# Altium schematic sheet PAGE09 Connectors.SchDoc
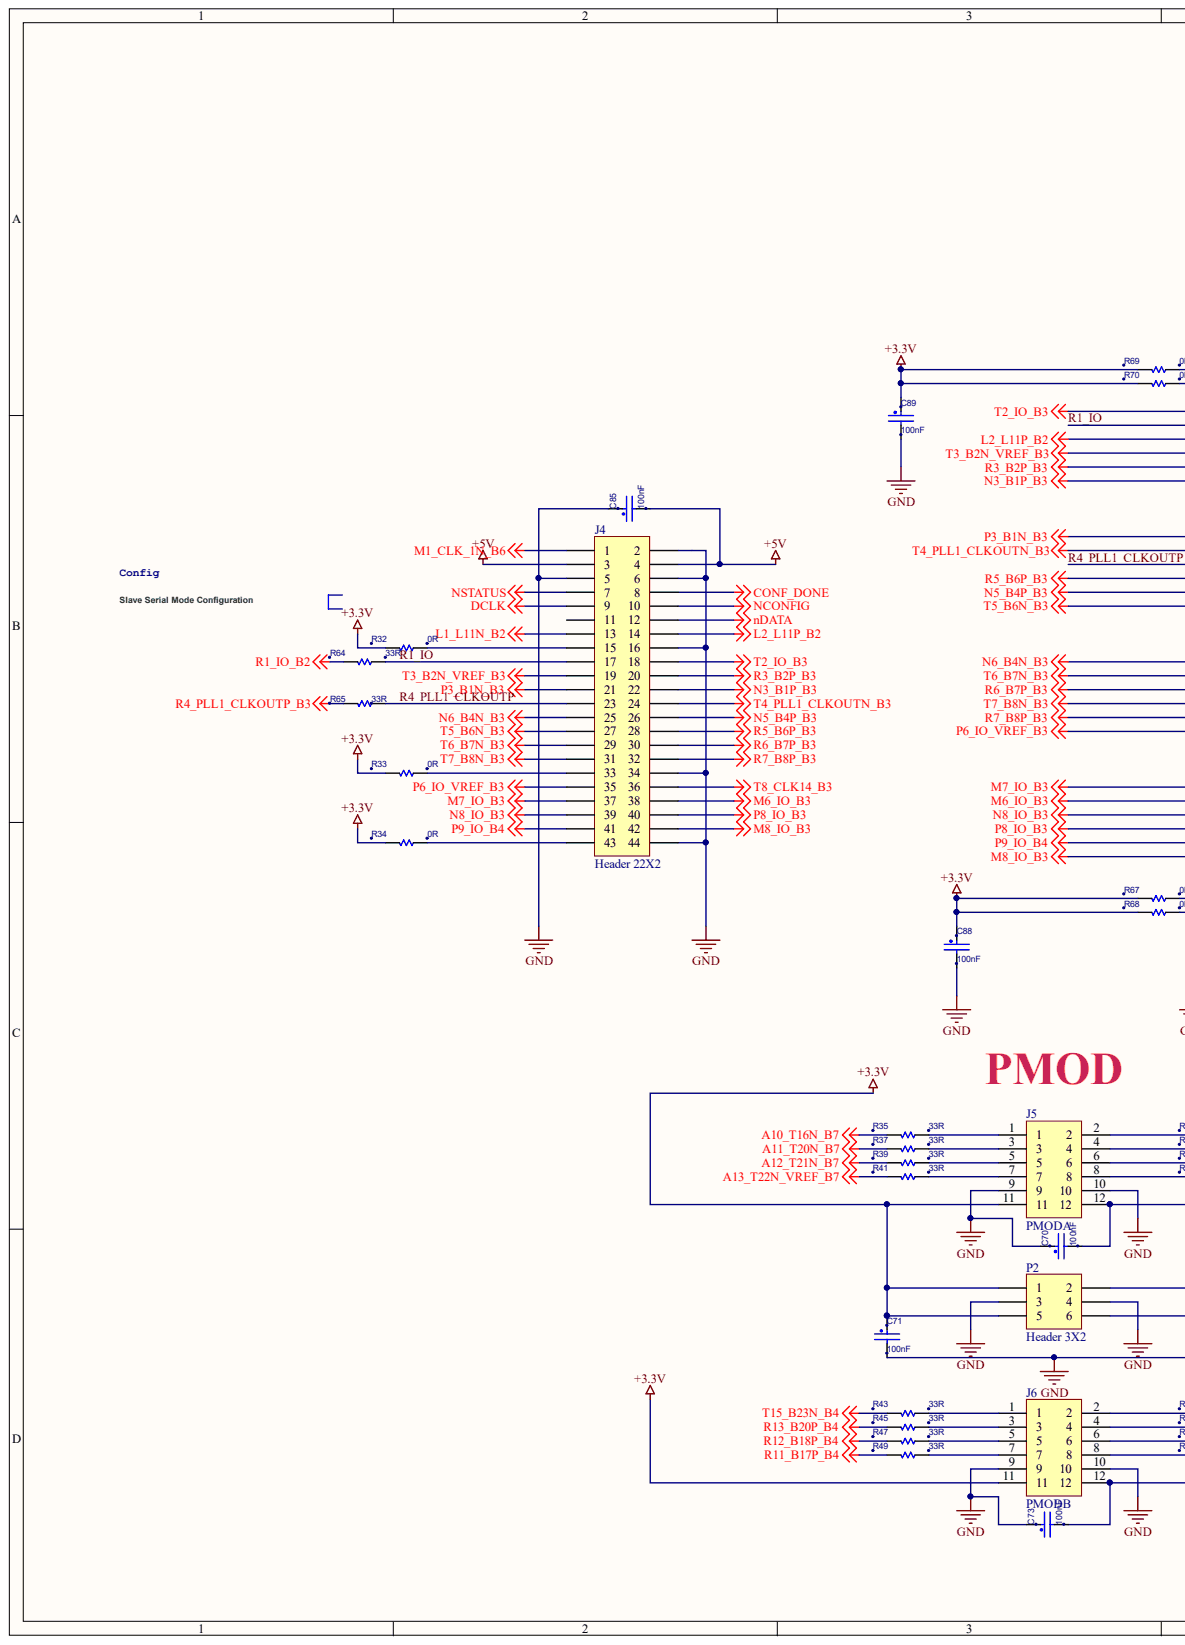
[diagram p0: PAGE09 Connectors.SchDoc, left]
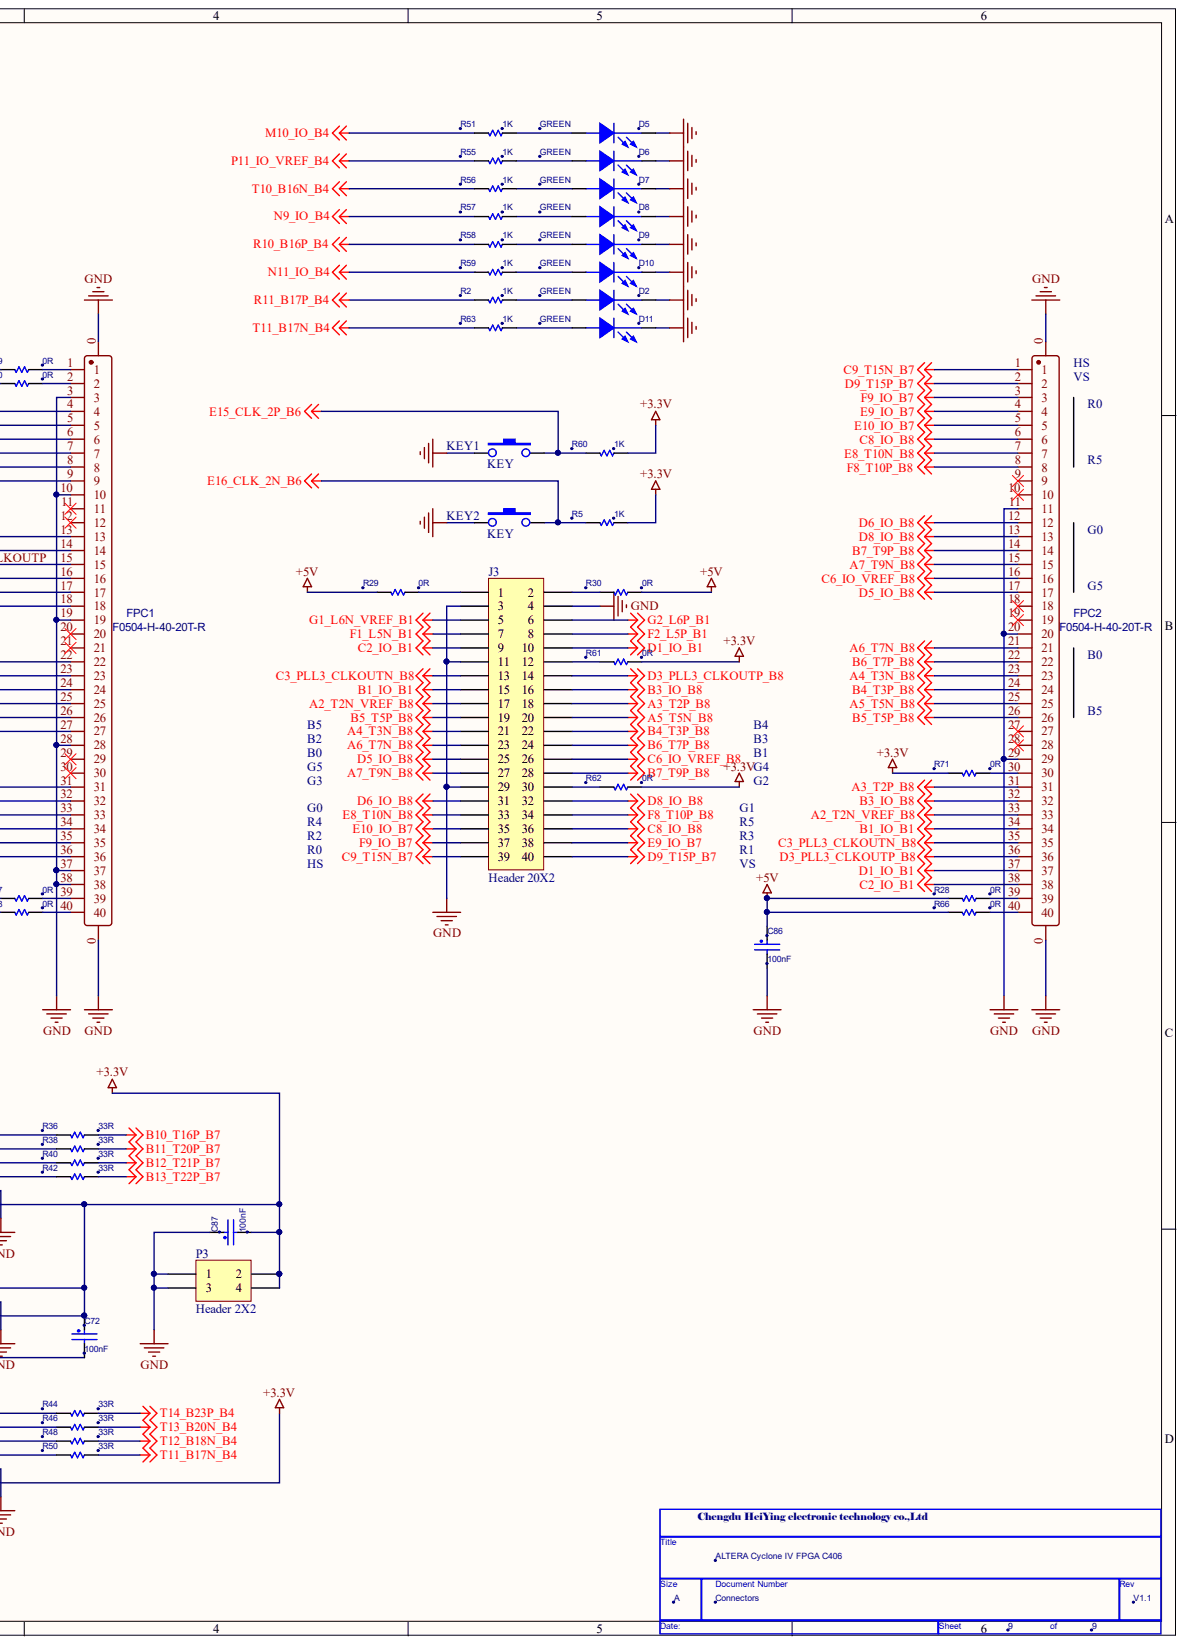
[diagram p1: PAGE09 Connectors.SchDoc, right]

## Parts
C70  100nF  [C0402]
C71  100nF  [C0402]
C72  100nF  [C0402]
C73  100nF  [C0402]
C85  100nF  [C0402]
C86  100nF  [C0402]
C87  100nF  [C0402]
C88  100nF  [C0402]
C89  100nF  [C0402]
D2  GREEN  [Q0603]
D5  GREEN  [Q0603]
D6  GREEN  [Q0603]
D7  GREEN  [Q0603]
D8  GREEN  [Q0603]
D9  GREEN  [Q0603]
D10  GREEN  [Q0603]
D11  GREEN  [Q0603]
FPC1  F0504-H-40-20T-R  [FPC-SMD_40P-P0.50_L5.8-W23.5]
FPC2  F0504-H-40-20T-R  [FPC-SMD_40P-P0.50_L5.8-W23.5]
J3  Header 20X2  [HDR2X20]
J4  Header 22X2  [HDR2X22]
J5  PMODA  [2X6P RIGHT]
J6  PMODB  [2X6P RIGHT]
KEY1  KEY  [SMD-3X6X2.5]
KEY2  KEY  [SMD-3X6X2.5]
P2  Header 3X2  [HDR2X3]
P3  Header 2X2  [HDR2X2]
R2  1K  [R0402]
R5  1K  [R0402]
R28  0R  [R0402]
R29  0R  [R0402]
R30  0R  [R0402]
R32  0R  [R0402]
R33  0R  [R0402]
R34  0R  [R0402]
R35  33R  [R0402]
R36  33R  [R0402]
R37  33R  [R0402]
R38  33R  [R0402]
R39  33R  [R0402]
R40  33R  [R0402]
R41  33R  [R0402]
R42  33R  [R0402]
R43  33R  [R0402]
R44  33R  [R0402]
R45  33R  [R0402]
R46  33R  [R0402]
R47  33R  [R0402]
R48  33R  [R0402]
R49  33R  [R0402]
R50  33R  [R0402]
R51  1K  [R0402]
R55  1K  [R0402]
R56  1K  [R0402]
R57  1K  [R0402]
R58  1K  [R0402]
R59  1K  [R0402]
R60  1K  [R0402]
R61  0R  [R0402]
R62  0R  [R0402]
R63  1K  [R0402]
R64  33R  [R0402]
R65  33R  [R0402]
R66  0R  [R0402]
R67  0R  [R0402]
R68  0R  [R0402]
R69  0R  [R0402]
R70  0R  [R0402]
R71  0R  [R0402]

## Nets
+3.3V: C70.2 C71.1 C72.1 C73.2 C87.2 C88.1 C89.1 J5.11 J5.12 J6.11 J6.12 P2.1 P2.2 P2.5 P2.6 P3.2 P3.4 R5.1 R32.2 R33.2 R34.2 R60.1 R61.1 R62.1 R67.2 R68.2 R69.2 R70.2 R71.2
+5V: C85.2 C86.1 J4.3 J4.4 R28.2 R29.2 R30.1 R66.2
A2_T2N_VREF_B8: FPC2.33 J3.17
A3_T2P_B8: FPC2.31 J3.18
A4_T3N_B8: FPC2.23 J3.21
A5_T5N_B8: FPC2.25 J3.20
A6_T7N_B8: FPC2.21 J3.23
A7_T9N_B8: FPC2.15 J3.27
A10_T16N_B7: R35.2
A11_T20N_B7: R37.2
A12_T21N_B7: R39.2
A13_T22N_VREF_B7: R41.2
B1_IO_B1: FPC2.34 J3.15
B3_IO_B8: FPC2.32 J3.16
B4_T3P_B8: FPC2.24 J3.22
B5_T5P_B8: FPC2.26 J3.19
B6_T7P_B8: FPC2.22 J3.24
B7_T9P_B8: FPC2.14 J3.28
B10_T16P_B7: R36.1
B11_T20P_B7: R38.1
B12_T21P_B7: R40.1
B13_T22P_B7: R42.1
C2_IO_B1: FPC2.38 J3.9
C3_PLL3_CLKOUTN_B8: FPC2.35 J3.13
C6_IO_VREF_B8: FPC2.16 J3.26
C8_IO_B8: FPC2.6 J3.36
C9_T15N_B7: FPC2.1 J3.39
CONF_DONE: J4.8
D1_IO_B1: FPC2.37 J3.10
D3_PLL3_CLKOUTP_B8: FPC2.36 J3.14
D5_IO_B8: FPC2.17 J3.25
D6_IO_B8: FPC2.12 J3.31
D8_IO_B8: FPC2.13 J3.32
D9_T15P_B7: FPC2.2 J3.40
DCLK: J4.9
E8_T10N_B8: FPC2.7 J3.33
E9_IO_B7: FPC2.4 J3.38
E10_IO_B7: FPC2.5 J3.35
E15_CLK_2P_B6: KEY1.3 R60.2
E16_CLK_2N_B6: KEY2.3 R5.2
F1_L5N_B1: J3.7
F2_L5P_B1: J3.8
F8_T10P_B8: FPC2.8 J3.34
F9_IO_B7: FPC2.3 J3.37
G1_L6N_VREF_B1: J3.5
G2_L6P_B1: J3.6
GND: C70.1 C71.2 C72.2 C73.1 C85.1 C86.2 C87.1 C88.2 C89.2 D2.2 D5.2 D6.2 D7.2 D8.2 D9.2 D10.2 D11.2 FPC1.0 FPC1.3 FPC1.10 FPC1.19 FPC1.28 FPC1.37 FPC1.38 FPC2.0 FPC2.11 FPC2.20 FPC2.29 J3.3 J3.4 J3.11 J3.29 J4.2 J4.5 J4.6 J4.16 J4.34 J4.44 J5.9 J5.10 J6.9 J6.10 KEY1.1 KEY2.1 P2.3 P2.4 P3.1 P3.3
L1_L11N_B2: J4.13
L2_L11P_B2: FPC1.6 J4.14
M1_CLK_1N_B6: J4.1
M6_IO_B3: FPC1.32 J4.38
M7_IO_B3: FPC1.31 J4.37
M8_IO_B3: FPC1.36 J4.42
M10_IO_B4: R51.2
N3_B1P_B3: FPC1.9 J4.22
N5_B4P_B3: FPC1.17 J4.26
N6_B4N_B3: FPC1.22 J4.25
N8_IO_B3: FPC1.33 J4.39
N9_IO_B4: R57.2
N11_IO_B4: R59.2
NCONFIG: J4.10
NDATA: J4.12
NSTATUS: J4.7
P3_B1N_B3: FPC1.13 J4.21
P6_IO_VREF_B3: FPC1.27 J4.35
P8_IO_B3: FPC1.34 J4.40
P9_IO_B4: FPC1.35 J4.41
P11_IO_VREF_B4: R55.2
R1_IO_B2: R64.2
R3_B2P_B3: FPC1.8 J4.20
R4_PLL1_CLKOUTP_B3: R65.2
R5_B6P_B3: FPC1.16 J4.28
R6_B7P_B3: FPC1.24 J4.30
R7_B8P_B3: FPC1.26 J4.32
R10_B16P_B4: R58.2
R11_B17P_B4: R2.2 R49.2
R12_B18P_B4: R47.2
R13_B20P_B4: R45.2
T2_IO_B3: FPC1.4 J4.18
T3_B2N_VREF_B3: FPC1.7 J4.19
T4_PLL1_CLKOUTN_B3: FPC1.14 J4.24
T5_B6N_B3: FPC1.18 J4.27
T6_B7N_B3: FPC1.23 J4.29
T7_B8N_B3: FPC1.25 J4.31
T8_CLK14_B3: J4.36
T10_B16N_B4: R56.2
T11_B17N_B4: R50.1 R63.2
T12_B18N_B4: R48.1
T13_B20N_B4: R46.1
T14_B23P_B4: R44.1
T15_B23N_B4: R43.2
R1_IO: FPC1.5 J4.17 R64.1
R4_PLL1_CLKOUTP: FPC1.15 J4.23 R65.1
NetD2_1: D2.1 R2.1
NetD5_1: D5.1 R51.1
NetD6_1: D6.1 R55.1
NetD7_1: D7.1 R56.1
NetD8_1: D8.1 R57.1
NetD9_1: D9.1 R58.1
NetD10_1: D10.1 R59.1
NetD11_1: D11.1 R63.1
NetFPC1_1: FPC1.1 R69.1
NetFPC1_2: FPC1.2 R70.1
NetFPC1_39: FPC1.39 R67.1
NetFPC1_40: FPC1.40 R68.1
NetFPC2_30: FPC2.30 R71.1
NetFPC2_39: FPC2.39 R28.1
NetFPC2_40: FPC2.40 R66.1
NetJ3_1: J3.1 R29.1
NetJ3_2: J3.2 R30.2
NetJ3_12: J3.12 R61.2
NetJ3_30: J3.30 R62.2
NetJ4_15: J4.15 R32.1
NetJ4_33: J4.33 R33.1
NetJ4_43: J4.43 R34.1
NetJ5_1: J5.1 R35.1
NetJ5_2: J5.2 R36.2
NetJ5_3: J5.3 R37.1
NetJ5_4: J5.4 R38.2
NetJ5_5: J5.5 R39.1
NetJ5_6: J5.6 R40.2
NetJ5_7: J5.7 R41.1
NetJ5_8: J5.8 R42.2
NetJ6_1: J6.1 R43.1
NetJ6_2: J6.2 R44.2
NetJ6_3: J6.3 R45.1
NetJ6_4: J6.4 R46.2
NetJ6_5: J6.5 R47.1
NetJ6_6: J6.6 R48.2
NetJ6_7: J6.7 R49.1
NetJ6_8: J6.8 R50.2

Not connected: FPC1 11 12 20 21 29 30; FPC2 9 10 18 19 27 28; J4 11

## Library data of the parts
C70-C73 C85-C89 = CAP_NP: CAP CER 0.1UF 6.3V 10% X5R 0201; AGILE_PN: 150-75429; CAD_APPROVED: Yes; FPLIST: CC0201,CC0201_HD,CC0201_3PIN,0201_CC; MFG_NAME01: MURATA; MFG_PN01: GRM033R60J104KE19D; Component Kind: Standard; HEIGHT: 0.33MM; ROHS: Yes; Tolerance: 10%
D2 D5-D11 = LED: ROOM: POWER; Component Kind: Standard
FPC1 FPC2 = F0504-H-40-20T-R: BOM_Manufacturer: XFCN; Contributor: LCSC; BOM_JLCPCB Part Class: Extended Part; BOM_Manufacturer Part: F0504-H-40-20T-R; BOM_Supplier: LCSC; BOM_Supplier Part: C481240; Component Kind: Standard; spicePre: F; spiceSymbolName: F0504-H-40-20T-R
J3 = Header 20X2: Header, 20-Pin, Dual row; Component Kind: Standard; LatestRevisionDate: 17-Jul-2002; LatestRevisionNote: Re-released for DXP Platform.; Library Name: Miscellaneous Connectors.IntLib; Signal Integrity: Connector; Publisher: Altium Limited
J4 = Header 22X2: Header, 22-Pin, Dual row; Component Kind: Standard; LatestRevisionDate: 17-Jul-2002; LatestRevisionNote: Re-released for DXP Platform.; Library Name: Miscellaneous Connectors.IntLib; Signal Integrity: Connector; Publisher: Altium Limited
J5 J6 = Female HDR2X6: Component Kind: Standard; Library Name: 排母.IntLib
P2 = Header 3X2: Header, 3-Pin, Dual row; Component Kind: Standard; LatestRevisionDate: 17-Jul-2002; LatestRevisionNote: Re-released for DXP Platform.; Library Name: Miscellaneous Connectors.IntLib; Signal Integrity: Connector; Publisher: Altium Limited
P3 = Header 2X2: Header, 2-Pin, Dual row; Component Kind: Standard; LatestRevisionDate: 17-Jul-2002; LatestRevisionNote: Re-released for DXP Platform.; Library Name: Miscellaneous Connectors.IntLib; Signal Integrity: Connector; Publisher: Altium Limited
R2 R5 R28-R30 R32-R51 R55-R71 = SMALL R1: Component Kind: Standard
KEY1 KEY2 = KEY: Component Kind: Standard; Library Name: 轻触开关.IntLib
